# Altium schematic sheet 05-MICROCONTROLEUR.SchDoc(05-MICROCONTROLEUR)
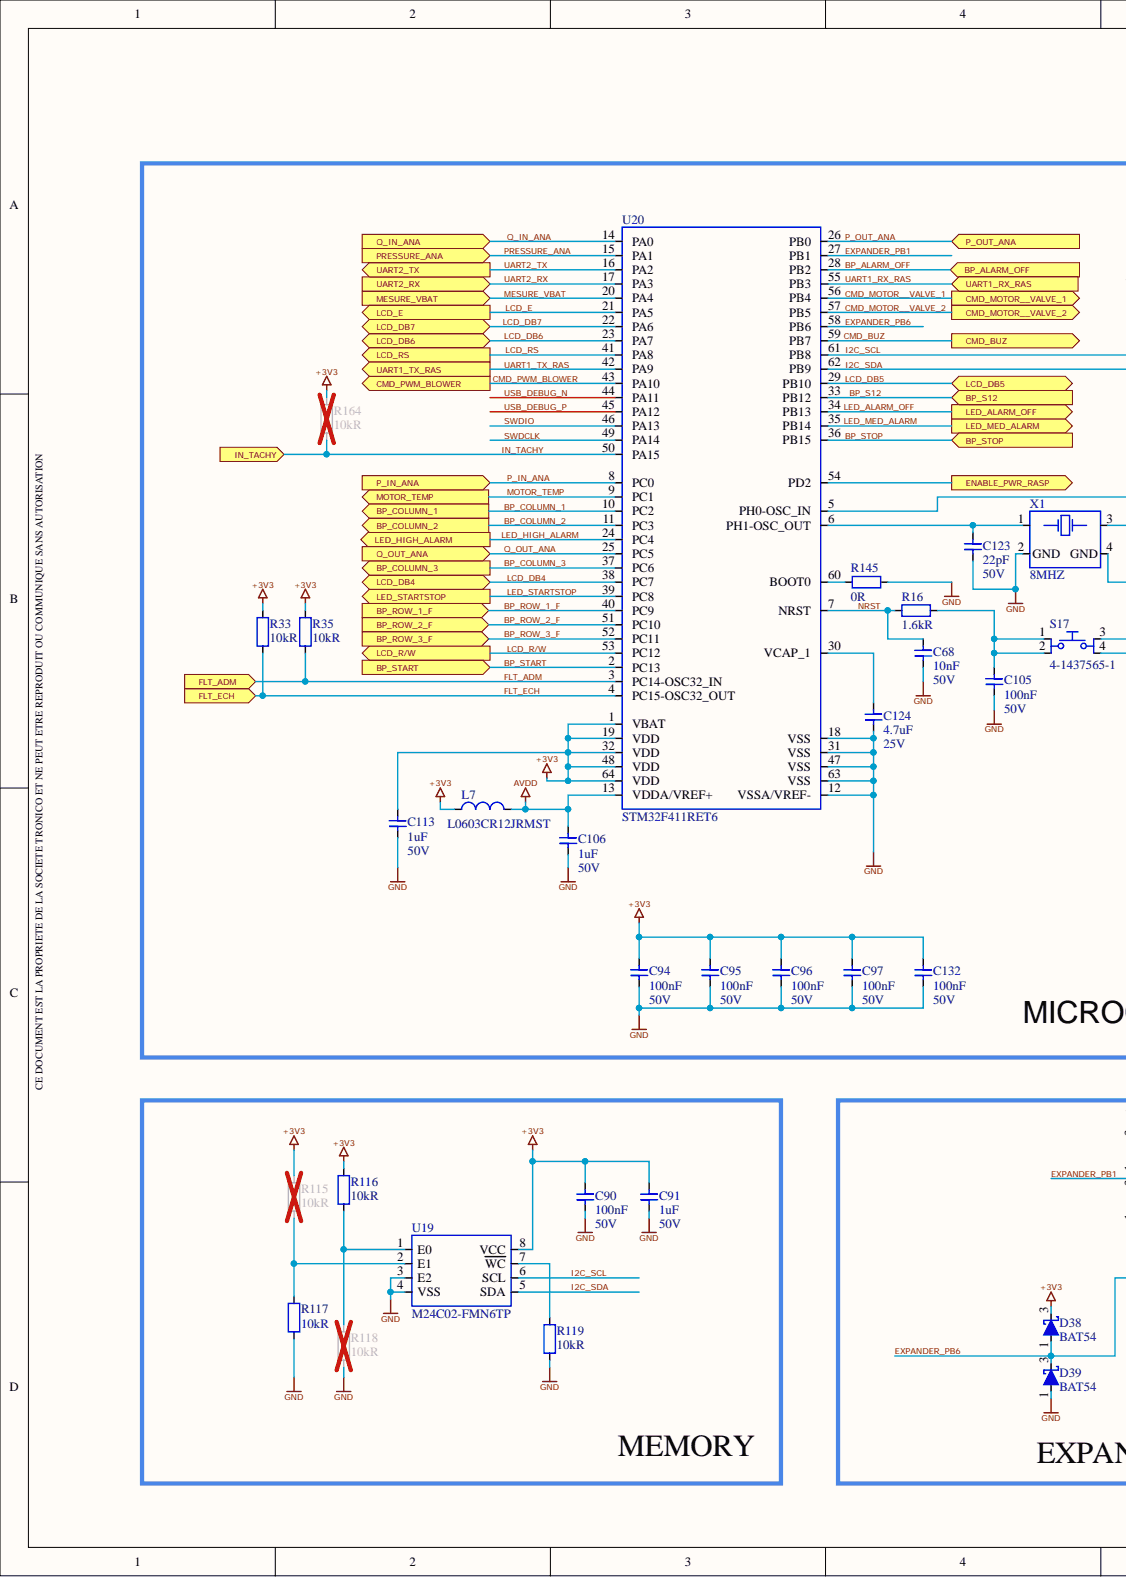
[diagram p0: 05-MICROCONTROLEUR.SchDoc, left]
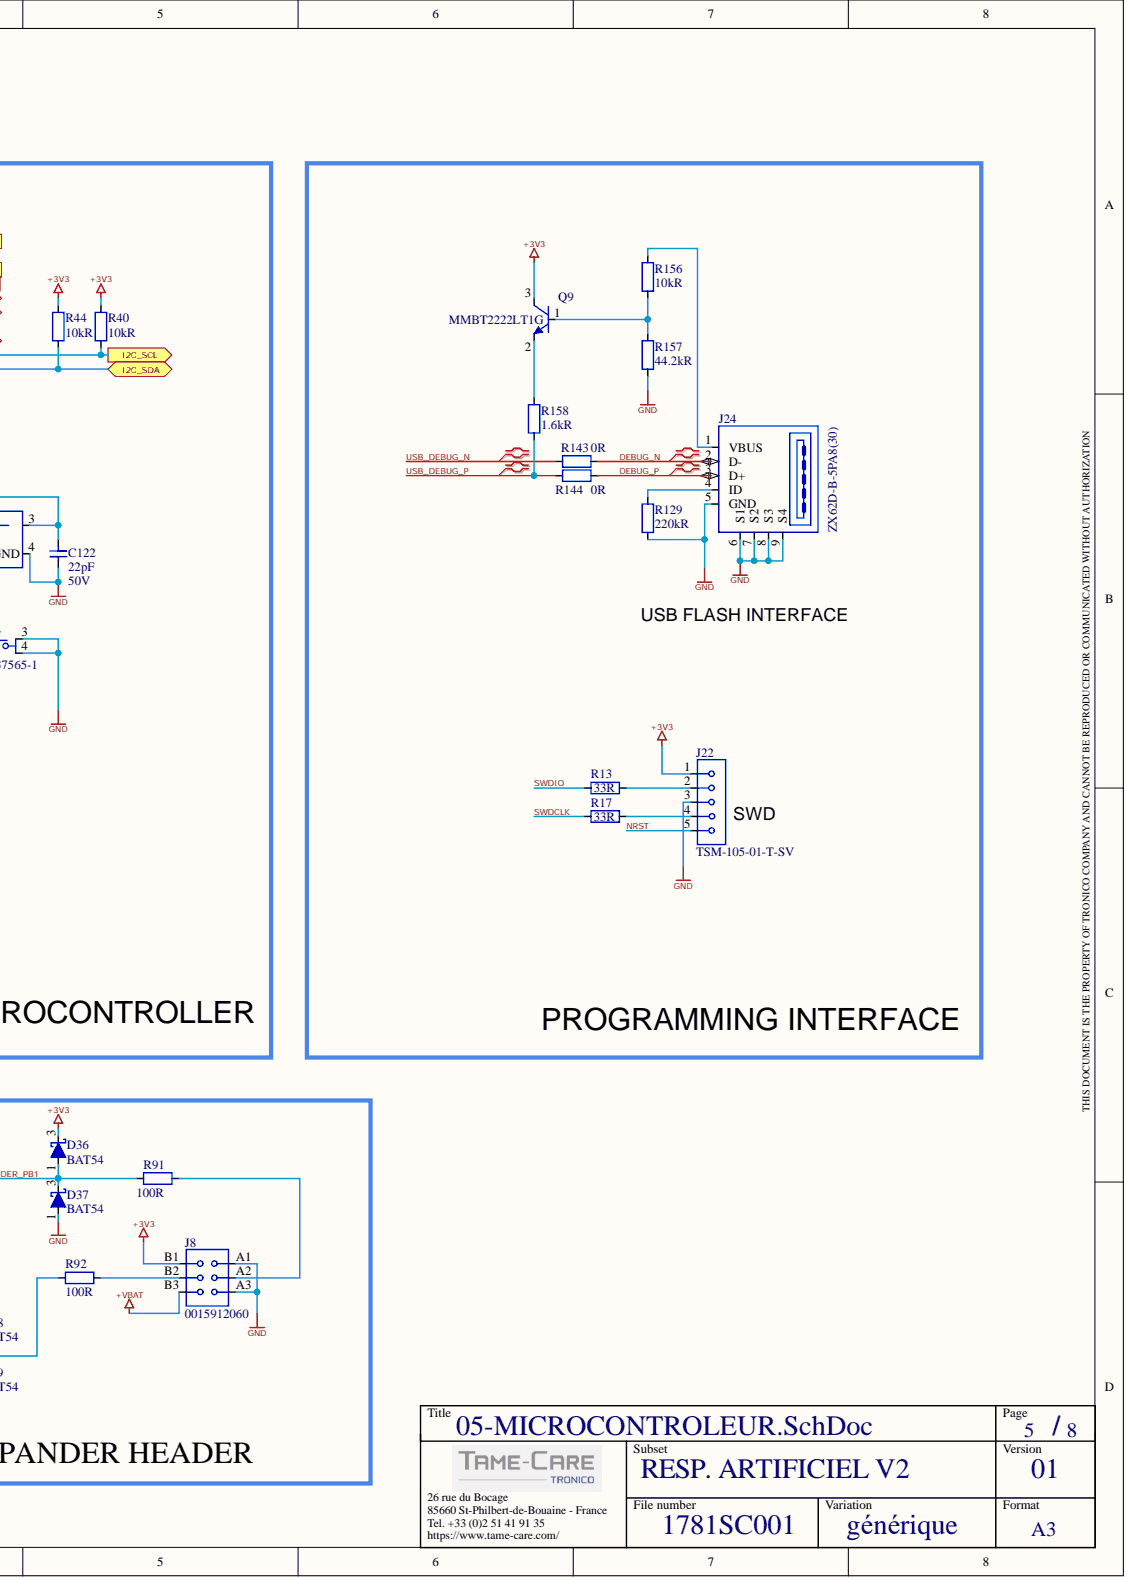
[diagram p1: 05-MICROCONTROLEUR.SchDoc, right]

## Parts
C68  10nF  Parameter 1: 50V  [CAPC_0603X080_T]
C90  100nF  Parameter 1: 50V  [CAPC_0603X094_T]
C91  1uF  Parameter 1: 50V  [CAPC_0603X080_T]
C94  100nF  Parameter 1: 50V  [CAPC_0603X094_T]
C95  100nF  Parameter 1: 50V  [CAPC_0603X094_T]
C96  100nF  Parameter 1: 50V  [CAPC_0603X094_T]
C97  100nF  Parameter 1: 50V  [CAPC_0603X094_T]
C105  100nF  Parameter 1: 50V  [CAPC_0603X094_T]
C106  1uF  Parameter 1: 50V  [CAPC_0603X080_T]
C113  1uF  Parameter 1: 50V  [CAPC_0603X080_T]
C122  22pF  Parameter 1: 50V  [CAPC_0603X090_T]
C123  22pF  Parameter 1: 50V  [CAPC_0603X090_T]
C124  4.7uF  Parameter 1: 25V  [CAPC_0603X080_T]
C132  100nF  Parameter 1: 50V  [CAPC_0603X094_T]
D36  BAT54  [SOT23_T]
D37  BAT54  [SOT23_T]
D38  BAT54  [SOT23_T]
D39  BAT54  [SOT23_T]
J8  0015912060  [CNT_MOLEX_15-91-2060_B]
J22  TSM-105-01-T-SV  [CNT_SAMTEC_TSM-105-01-X-SV_B]
J24  ZX62D-B-5PA8(30)  [CNT_HIROSE_ZX62D_B_5P8_B]
L7  L0603CR12JRMST  [IND_0603X080_T]
Q9  MMBT2222LT1G  [SOT23-DI]
R13  33R  [RESC_0603X045_T]
R16  1.6kR  [RESC_0603X045_T]
R17  33R  [RESC_0603X045_T]
R33  10kR  [RESC_0603X045_T]
R35  10kR  [RESC_0603X045_T]
R40  10kR  [RESC_0603X045_T]
R44  10kR  [RESC_0603X045_T]
R91  100R  [RESC_0603X045_T]
R92  100R  [RESC_0603X045_T]
R115  10kR  [RESC_0603X045_T]
R116  10kR  [RESC_0603X045_T]
R117  10kR  [RESC_0603X045_T]
R118  10kR  [RESC_0603X045_T]
R119  10kR  [RESC_0603X045_T]
R129  220kR  [RESC_0603X045_T]
R143  0R  [RESC_0603X045_T]
R144  0R  [RESC_0603X045_T]
R145  0R  [RESC_0603X045_T]
R156  10kR  [RESC_0603X045_T]
R157  44.2kR  [RESC_0603X045_T]
R158  1.6kR  [RESC_0603X045_T]
R164  10kR  [RESC_0603X045_T]
S17  4-1437565-1  [PushButon_TE-4-1437565-1_B]
U19  M24C02-FMN6TP  [SO8_1.27_5.0X4.0X1.75_B]
U20  STM32F411RET6  [LQFP64_0.5_10X10X1.6_B]
X1  8MHZ  [XTAL_ABRACON_ABMM2_B]

## Ports
BP_ALARM_OFF, BP_COLUMN_1, BP_COLUMN_2, BP_COLUMN_3, BP_ROW_1_F, BP_ROW_2_F, BP_ROW_3_F, BP_S12, BP_START, BP_STOP, CMD_BUZ, CMD_MOTOR__VALVE_1, CMD_MOTOR__VALVE_2, CMD_PWM_BLOWER, ENABLE_PWR_RASP, FLT_ADM, FLT_ECH, I2C_SCL, I2C_SDA, IN_TACHY, LCD_DB4, LCD_DB5, LCD_DB6, LCD_DB7, LCD_E, LCD_R/W, LCD_RS, LED_ALARM_OFF, LED_HIGH_ALARM, LED_MED_ALARM, LED_STARTSTOP, MESURE_VBAT, MOTOR_TEMP, P_IN_ANA, P_OUT_ANA, PRESSURE_ANA, Q_IN_ANA, Q_OUT_ANA, UART1_RX_RAS, UART1_TX_RAS, UART2_RX, UART2_TX

## Nets
+3V3: C90.2 C91.2 C94.2 C95.1 C96.2 C97.2 C113.2 C132.2 D36.3 D38.3 J8.B1 J22.1 L7.1 Q9.3 R33.1 R35.1 R40.2 R44.1 R115.1 R116.1 R164.1 U19.8(VCC) U20.1(VBAT) U20.19(VDD) U20.32(VDD) U20.48(VDD) U20.64(VDD)
+VBAT: J8.B3
AVDD: C106.2 L7.2 U20.13(VDDA/VREF+)
GND: C68.1 C90.1 C91.1 C94.1 C95.2 C96.1 C97.1 C105.1 C106.1 C113.1 C122.1 C123.1 C124.1 C132.1 D37.1 D39.1 J8.A1 J8.A3 J22.3 J24.5(GND) J24.6(S1) J24.7(S2) J24.8(S3) J24.9(S4) R117.2 R118.2 R119.2 R129.2 R145.1 R157.2 S17.3 S17.4 U19.3(E2) U19.4(VSS) U20.12(VSSA/VREF-) U20.18(VSS) U20.31(VSS) U20.47(VSS) U20.63(VSS) X1.2(GND) X1.4(GND)
BP_ALARM_OFF: U20.28(PB2) ; port BP_ALARM_OFF
BP_COLUMN_1: U20.10(PC2) ; port BP_COLUMN_1
BP_COLUMN_2: U20.11(PC3) ; port BP_COLUMN_2
BP_COLUMN_3: U20.37(PC6) ; port BP_COLUMN_3
BP_ROW_1_F: U20.40(PC9) ; port BP_ROW_1_F
BP_ROW_2_F: U20.51(PC10) ; port BP_ROW_2_F
BP_ROW_3_F: U20.52(PC11) ; port BP_ROW_3_F
BP_S12: U20.33(PB12) ; port BP_S12
BP_START: U20.2(PC13) ; port BP_START
BP_STOP: U20.36(PB15) ; port BP_STOP
CMD_BUZ: U20.59(PB7) ; port CMD_BUZ
CMD_MOTOR__VALVE_1: U20.56(PB4) ; port CMD_MOTOR__VALVE_1
CMD_MOTOR__VALVE_2: U20.57(PB5) ; port CMD_MOTOR__VALVE_2
CMD_PWM_BLOWER: U20.43(PA10) ; port CMD_PWM_BLOWER
DEBUG_N: J24.2(D-) R143.1
DEBUG_P: J24.3(D+) R144.1
ENABLE_PWR_RASP: U20.54(PD2) ; port ENABLE_PWR_RASP
EXPANDER_PB1: D36.1 D37.3 R91.2 U20.27(PB1)
EXPANDER_PB6: D38.1 D39.3 R92.2 U20.58(PB6)
FLT_ADM: R35.2 U20.3(PC14-OSC32_IN) ; port FLT_ADM
FLT_ECH: R33.2 U20.4(PC15-OSC32_OUT) ; port FLT_ECH
I2C_SCL: R40.1 U19.6(SCL) U20.61(PB8) ; port I2C_SCL
I2C_SDA: R44.2 U19.5(SDA) U20.62(PB9) ; port I2C_SDA
IN_TACHY: R164.2 U20.50(PA15) ; port IN_TACHY
LCD_DB4: U20.38(PC7) ; port LCD_DB4
LCD_DB5: U20.29(PB10) ; port LCD_DB5
LCD_DB6: U20.23(PA7) ; port LCD_DB6
LCD_DB7: U20.22(PA6) ; port LCD_DB7
LCD_E: U20.21(PA5) ; port LCD_E
LCD_R/W: U20.53(PC12) ; port LCD_R/W
LCD_RS: U20.41(PA8) ; port LCD_RS
LED_ALARM_OFF: U20.34(PB13) ; port LED_ALARM_OFF
LED_HIGH_ALARM: U20.24(PC4) ; port LED_HIGH_ALARM
LED_MED_ALARM: U20.35(PB14) ; port LED_MED_ALARM
LED_STARTSTOP: U20.39(PC8) ; port LED_STARTSTOP
MESURE_VBAT: U20.20(PA4) ; port MESURE_VBAT
MOTOR_TEMP: U20.9(PC1) ; port MOTOR_TEMP
NRST: C68.2 J22.5 R16.1 U20.7(NRST)
P_IN_ANA: U20.8(PC0) ; port P_IN_ANA
P_OUT_ANA: U20.26(PB0) ; port P_OUT_ANA
PRESSURE_ANA: U20.15(PA1) ; port PRESSURE_ANA
Q_IN_ANA: U20.14(PA0) ; port Q_IN_ANA
Q_OUT_ANA: U20.25(PC5) ; port Q_OUT_ANA
SWDCLK: R17.2 U20.49(PA14)
SWDIO: R13.2 U20.46(PA13)
UART1_RX_RAS: U20.55(PB3) ; port UART1_RX_RAS
UART1_TX_RAS: U20.42(PA9) ; port UART1_TX_RAS
UART2_RX: U20.17(PA3) ; port UART2_RX
UART2_TX: U20.16(PA2) ; port UART2_TX
USB_DEBUG_N: R143.2 U20.44(PA11)
USB_DEBUG_P: R144.2 R158.2 U20.45(PA12)
NetC105_2: C105.2 R16.2 S17.1 S17.2
NetC122_2: C122.2 U20.5(PH0-OSC_IN) X1.3
NetC123_2: C123.2 U20.6(PH1-OSC_OUT) X1.1
NetC124_2: C124.2 U20.30(VCAP_1)
NetJ8_A2: J8.A2 R91.1
NetJ8_B2: J8.B2 R92.1
NetJ22_2: J22.2 R13.1
NetJ22_4: J22.4 R17.1
NetJ24_1: J24.1(VBUS) R156.1
NetJ24_4: J24.4(ID) R129.1
NetQ9_1: Q9.1 R156.2 R157.1
NetQ9_2: Q9.2 R158.1
NetR115_2: R115.2 R117.1 U19.2(E1)
NetR116_2: R116.2 R118.1 U19.1(E0)
NetR119_1: R119.1 U19.7(WC)
NetR145_2: R145.2 U20.60(BOOT0)

## Library data of the parts
C68 = 23U00104: COND_CERAM CMS 0603 X7R 10nF 50V 10%; REV_NOTE: Maj Champs Techniques; REV_AUTHOR: nlelievre; REF_FAB: C0603C103K5RACTU; LEVEL: 1; FAMILLE: CAPACITIFS; FAB: KEMET; Database Table Name: v_bt_all; CHAMP9: -55°C/+125°C; CHAMP2: 0603; SS_FAMILLE: COND_CERAM; Simulation: CAP; Signal Integrity: Cap; REV_DATE: 16/10/2019 13:28:44; Library Name: SVNDatabase_BaseTechnique.SVNDbLib; ID_RnD: 23U00104; HelpURL: http://bt_rnd/ds/02_capacitif/KEMET/KEMET_KEM_C1002_X7R_SMD.pdf; ComponentLink2Description: Manufacturer Website; ComponentLink1Description: Manufacturer Datasheet; Component Kind: Standard; CHAMP7: 10%; CHAMP6: 50V; CHAMP5: 10nF; CHAMP3: X7R; CHAMP1: CMS; ACTIF: ACTIF
C90 C94-C97 C105 C132 = 23U00036: COND_CERAM CMS 0603 X7R 100nF 50V 10%; REV_NOTE: Maj Champs Techniques; REV_AUTHOR: nlelievre; REF_FAB: 06035C104KAT2A; LEVEL: 1; FAMILLE: CAPACITIFS; FAB: AVX; Database Table Name: v_bt_all; CHAMP9: -55°C/+125°C; CHAMP2: 0603; SS_FAMILLE: COND_CERAM; Simulation: CAP; Signal Integrity: Cap; REV_DATE: 16/10/2019 13:20:57; Library Name: SVNDatabase_BaseTechnique.SVNDbLib; ID_RnD: 23U00036; HelpURL: http://Bt_rnd/ds/02_capacitif/AVX/AVX_cx7r.pdf; ComponentLink2Description: Manufacturer Website; ComponentLink1Description: Manufacturer Datasheet; Component Kind: Standard; CHAMP7: 10%; CHAMP6: 50V; CHAMP5: 100nF; CHAMP3: X7R; CHAMP1: CMS; ACTIF: ACTIF
C91 C106 C113 = 23U00347: COND_CERAM CMS 0603 X5R 1uF 50V 10%; REV_NOTE: Maj Champs Techniques; REV_AUTHOR: nlelievre; REF_FAB: CGA3E3X5R1H105K080AB; LEVEL: 1; FAMILLE: CAPACITIFS; FAB: TDK; Database Table Name: v_bt_all; CHAMP9: -55°C/+85°C; CHAMP2: 0603; SS_FAMILLE: COND_CERAM; Simulation: CAP; Signal Integrity: Cap; REV_DATE: 16/10/2019 13:35:17; Library Name: SVNDatabase_BaseTechnique.SVNDbLib; ID_RnD: 23U00347; HelpURL: http://bt_rnd/ds/02_capacitif/TDK/mlccspec_automotive_general_midvoltage_en.pdf; ComponentLink2Description: Manufacturer Website; ComponentLink1Description: Manufacturer Datasheet; Component Kind: Standard; CHAMP7: 10%; CHAMP6: 50V; CHAMP5: 1uF; CHAMP3: X5R; CHAMP1: CMS; ACTIF: ACTIF
C122 C123 = 23U00046: COND_CERAM CMS 0603 C0G 22pF 50V 10%; REV_NOTE: MaJ Champs Techniques; REV_AUTHOR: lclavier; REF_FAB: C0603C220K5GACTU; LEVEL: 1; FAMILLE: CAPACITIFS; FAB: KEMET; Database Table Name: v_bt_all; CHAMP9: -55°C/+125°C; CHAMP2: 0603; SS_FAMILLE: COND_CERAM; Simulation: CAP; Signal Integrity: Cap; REV_DATE: 02/05/2020 13:19:47; Library Name: SVNDatabase_BaseTechnique.SVNDbLib; ID_RnD: 23U00046; HelpURL: http://Bt_rnd/ds/02_capacitif/AVX/AVX_cx7r.pdf; ComponentLink3URL: None; ComponentLink3Description: Qualification information; ComponentLink2URL: http://www.avx.com; ComponentLink2Description: Manufacturer Website; ComponentLink1URL: http://www.avx.com/docs/Catalogs/cx7r.pdf; ComponentLink1Description: Manufacturer Datasheet; Component Kind: Standard; CHAMP7: 10%; CHAMP6: 50V; CHAMP5: 22pF; CHAMP3: C0G; CHAMP1: CMS; ACTIF: ACTIF
C124 = 23U00282: COND_CERAM CMS 0603 X5R 4.7uF 25V 10%; REV_NOTE: Maj Champs Techniques; REV_AUTHOR: nlelievre; REF_FAB: GRM188R61E475KE15D; LEVEL: 1; FAMILLE: CAPACITIFS; FAB: MURATA; Database Table Name: v_bt_all; CHAMP9: -55°C/+85°C; CHAMP2: 0603; SS_FAMILLE: COND_CERAM; Simulation: CAP; Signal Integrity: Cap; REV_DATE: 16/10/2019 13:33:44; Library Name: SVNDatabase_BaseTechnique.SVNDbLib; ID_RnD: 23U00282; HelpURL: http://bt_rnd/ds/02_capacitif/murata/GRM18_series.pdf; ComponentLink2Description: Manufacturer Website; ComponentLink1Description: Manufacturer Datasheet; Component Kind: Standard; CHAMP7: 10%; CHAMP6: 25V; CHAMP5: 4.7uF; CHAMP3: X5R; CHAMP1: CMS; ACTIF: ACTIF
D36-D39 = 33U00013: DIODE_DE_SIGNAL CMS SOT23  30V 200mA -55°C/+150°C -55°C/+150°C; REV_NOTE: MàJ footprint_path et footprint_ref; REV_AUTHOR: llannuzel; QUALIF: None; LEVEL: 1; FAMILLE: DIODES; FAB: FAIRCHILD; Database Table Name: v_bt_all; SS_FAMILLE: DIODE_DE_SIGNAL; Simulation: SKYDIODE; Signal Integrity: D Schottky; REV_DATE: 13/12/2019 10:03:18; REF_FAB: BAT54; ID_RnD: 33U00013; HelpURL: http://bt_rnd/ds/03_diode/FAIRCHILD/BAT54_x.pdf; ComponentLink2Description: Manufacturer Website; ComponentLink1Description: Manufacturer Datasheet; Component Kind: Standard; CHAMP10: -55°C/+150°C; CHAMP9: -55°C/+150°C; CHAMP6: 200mA; CHAMP5: 30V; CHAMP2: SOT23; CHAMP1: CMS; ACTIF: ACTIF; Library Name: SVNDatabase_BaseTechnique.SVNDbLib
J8 = A7U00323: CONNECT C-Grid CMS 2x3PTS EMBASE RECT MALE DROIT; REV_NOTE: Migration vers t_bt; REV_AUTHOR: llannuzel; LEVEL: 1; FAMILLE: CONNECTIQUE; FAB: MOLEX; Database Table Name: v_bt_all; CHAMP9: -40°C/+105°C; SS_FAMILLE: CONNECT; REV_DATE: 03/05/2020 17:29:11; REF_FAB: 0015912060; ID_RnD: A7U00323; HelpURL: http://bt_rnd/ds/10_connectique/MOLEX/015912060_sd.pdf; ComponentLink2Description: Manufacturer Website; ComponentLink1Description: Manufacturer Datasheet; Component Kind: Standard; CHAMP8: DROIT; CHAMP7: MALE; CHAMP6: RECT; CHAMP5: EMBASE; CHAMP4: 2x3PTS; CHAMP3: CMS; CHAMP1: C-Grid; ACTIF: ACTIF; Library Name: SVNDatabase_BaseTechnique.SVNDbLib
J22 = A7U00320: CONNECT TSM CMS 5PTS EMBASE RECT MALE DROIT; Valide: OK; REV_NOTE: Migration vers t_bt; REV_AUTHOR: lclavier; LEVEL: 1; FAMILLE: CONNECTIQUE; FAB: SAMTEC; Database Table Name: v_bt_all; CHAMP9: -55°C/+105°C; SS_FAMILLE: CONNECT; REV_DATE: 24/04/2020 18:20:39; REF_FAB: TSM-105-01-T-SV; ID_RnD: A7U00320; HelpURL: http://bt_rnd/ds/10_connectique/SAMTEC/tsm-1xx-xx-xxx-sv-xx-xxx-xx-mkt.pdf; ComponentLink3URL: None; ComponentLink3Description: None; ComponentLink2URL: None; ComponentLink2Description: Manufacturer Website; ComponentLink1URL: http://bt_rnd/ds/10_connectique/SAMTEC/tsm-1xx-xx-xxx-sv-xx-xxx-xx-mkt.pdf; ComponentLink1Description: Footprint; Component Kind: Standard; CHAMP8: DROIT; CHAMP7: MALE; CHAMP6: RECT; CHAMP5: EMBASE; CHAMP4: 5PTS; CHAMP3: CMS; CHAMP1: TSM; ACTIF: ACTIF; Library Name: SVNDatabase_BaseTechnique.SVNDbLib
J24 = A7U00249: CONNECT USB CMS 5PTS EMBASE EMBASE RECT FEM COUDE -30°C/+85°C; REV_NOTE: MaJ Champs Techniques; REV_AUTHOR: lclavier; QUALIF: None; LEVEL: 1; FAMILLE: CONNECTIQUE; FAB: HIROSE; Database Table Name: v_bt_all; SS_FAMILLE: CONNECT; REV_DATE: 25/02/2019 13:48:49; REF_FAB: ZX62D-B-5PA8(30); Library Name: SVNDatabase_BaseTechnique.SVNDbLib; ID_RnD: A7U00249; HelpURL: http://bt_rnd/ds/10_connectique/hirose/ZX_Series.pdf; ComponentLink2Description: Manufacturer Website; ComponentLink1URL: https://www.hirose.com/product/en/products/ZX/ZX62D-B-5PA8(30)/; ComponentLink1Description: Manufacturer Datasheet; Component Kind: Standard; CHAMP9: -30°C/+85°C; CHAMP8: COUDE; CHAMP7: FEM; CHAMP6: RECT; CHAMP5: EMBASE; CHAMP4: 5PTS; CHAMP3: CMS; CHAMP1: USB; ACTIF: ACTIF
L7 = 42U00090: SELF CMS 0603  120nH 5% 300mA -40°C/+85°C; REV_NOTE: MAJ Champ HelpURL; REV_AUTHOR: lclavier; QUALIF: None; LEVEL: 1; FAMILLE: INDUCTIFS; FAB: KEMET; Database Table Name: v_bt_all; CHAMP2: 0603; SS_FAMILLE: SELF; Simulation: INDUCTOR; Signal Integrity: Inductor; REV_DATE: 13/03/2019 17:58:10; REF_FAB: L0603CR12JRMST; ID_RnD: 42U00090; HelpURL: http://BT_RND/ds/04_inductif/KEMET/Kemet_L-RMSSeries.pdf; ComponentLink2Description: Manufacturer Website; ComponentLink1Description: Manufacturer Datasheet; Component Kind: Standard; CHAMP9: -40°C/+85°C; CHAMP7: 300mA; CHAMP6: 5%; CHAMP5: 120nH; CHAMP1: CMS; ACTIF: ACTIF; Library Name: SVNDatabase_BaseTechnique.SVNDbLib
Q9 = 91U00003: TRANSISTOR_BIP CMS SOT23 NPN 30V +0.6A -55°C/+150°C -55°C/+150°C; REV_NOTE: Maj Library Ref & Library Path; REV_AUTHOR: jcaille; LEVEL: 1; FAMILLE: TRANSISTORS; FAB: ON SEMICONDUCTOR; Database Table Name: v_bt_all; SS_FAMILLE: TRANSISTOR_BIP; REV_DATE: 22/01/2020 16:00:13; REF_FAB: MMBT2222LT1G; ID_RnD: 91U00003; HelpURL: http://172.17.1.234/ds/09_transistor/ON%20semi/MMBT2222LT1-D.pdf; ComponentLink2Description: Manufacturer Website; ComponentLink1Description: Manufacturer Datasheet; Component Kind: Standard; CHAMP10: -55°C/+150°C; CHAMP9: -55°C/+150°C; CHAMP7: +0.6A; CHAMP6: 30V; CHAMP5: NPN; CHAMP2: SOT23; CHAMP1: CMS; ACTIF: ACTIF; Library Name: SVNDatabase_BaseTechnique.SVNDbLib
R13 R17 = 11U01018: REST CMS 0603 100PPM 33R 1/10W 5% 75V; Valide / Non Valide: OK; REV_NOTE: Migration vers t_bt; REV_AUTHOR: lclavier; REF_FAB: RC0603JR-0733RL; LEVEL: 1; FAMILLE: RESISTIFS; FAB: YAGEO; Database Table Name: v_bt_all; CHAMP9: -55°C/+155°C; CHAMP2: 0603; SS_FAMILLE: REST; Simulation: RESISTOR; Signal Integrity: Res; REV_DATE: 20/04/2020 18:36:50; ID_RnD: 11U01018; HelpURL: http://bt_rnd/ds/01_resistif/yageo/RC-L_Series.pdf; ComponentLink2Description: Manufacturer Website; ComponentLink1Description: Manufacturer Datasheet; Component Kind: Standard; CHAMP8: 75V; CHAMP7: 5%; CHAMP6: 1/10W; CHAMP5: 33R; CHAMP3: 100PPM; CHAMP1: CMS; ACTIF: ACTIF; Library Name: SVNDatabase_BaseTechnique.SVNDbLib
R16 R158 = 11U01012: REST CMS 0603 100PPM 1.6kR 1/10W 1% 75V; Valide / Non Valide: OK; REV_NOTE: Migration vers t_bt; REV_AUTHOR: jcaille; REF_FAB: CRCW06031K60FKEA; LEVEL: 1; FAMILLE: RESISTIFS; FAB: VISHAY; Database Table Name: v_bt_all; CHAMP9: -55°C/+155°C; CHAMP2: 0603; SS_FAMILLE: REST; Simulation: RESISTOR; Signal Integrity: Res; REV_DATE: 17/04/2020 11:05:27; ID_RnD: 11U01012; HelpURL: http://bt_rnd/ds/01_resistif/Vishay/dcrcwe3.pdf; ComponentLink2Description: Manufacturer Website; ComponentLink1Description: Manufacturer Datasheet; Component Kind: Standard; CHAMP8: 75V; CHAMP7: 1%; CHAMP6: 1/10W; CHAMP5: 1.6kR; CHAMP3: 100PPM; CHAMP1: CMS; ACTIF: ACTIF; Library Name: SVNDatabase_BaseTechnique.SVNDbLib
R33 R35 R40 R44 R115-R119 R156 R164 = 11U00108: REST CMS 0603 100PPM 10kR 1/10W 1% -55°C/+155°C; Valide / Non Valide: OK; REV_NOTE: MaJ description; REV_AUTHOR: jrenvoise; REF_FAB: RC0603FR-0710KL; LEVEL: 1; FAMILLE: RESISTIFS; FAB: YAGEO; Database Table Name: v_bt_all; CHAMP2: 0603; SS_FAMILLE: REST; Simulation: RESISTOR; Signal Integrity: Res; REV_DATE: 12/09/2018 10:54:26; ID_RnD: 11U00108; HelpURL: http://bt_rnd/ds/01_resistif/yageo/RC-L_Series.pdf; ComponentLink2Description: Manufacturer Website; ComponentLink1Description: Manufacturer Datasheet; Component Kind: Standard; CHAMP9: -55°C/+155°C; CHAMP7: 1%; CHAMP6: 1/10W; CHAMP5: 10kR; CHAMP3: 100PPM; CHAMP1: CMS; ACTIF: ACTIF; Library Name: SVNDatabase_BaseTechnique.SVNDbLib
R91 R92 = 11U00071: REST CMS 0603 100PPM 100R 1/10W 1% -55°C/+155°C; Valide / Non Valide: OK; REV_NOTE: MaJ description; REV_AUTHOR: jrenvoise; REF_FAB: RC0603FR-07100RL; LEVEL: 1; FAMILLE: RESISTIFS; FAB: YAGEO; Database Table Name: v_bt_all; CHAMP2: 0603; SS_FAMILLE: REST; Simulation: RESISTOR; Signal Integrity: Res; REV_DATE: 12/09/2018 10:54:26; ID_RnD: 11U00071; HelpURL: http://bt_rnd/ds/01_resistif/yageo/RC-L_Series.pdf; ComponentLink2Description: Manufacturer Website; ComponentLink1Description: Manufacturer Datasheet; Component Kind: Standard; CHAMP9: -55°C/+155°C; CHAMP7: 1%; CHAMP6: 1/10W; CHAMP5: 100R; CHAMP3: 100PPM; CHAMP1: CMS; ACTIF: ACTIF; Library Name: SVNDatabase_BaseTechnique.SVNDbLib
R129 = 11U01019: REST CMS 0603 100PPM 220kR 1/10W 1% 75V; Valide / Non Valide: OK; REV_NOTE: Migration vers t_bt; REV_AUTHOR: lclavier; REF_FAB: RC0603FR-07220KL; LEVEL: 1; FAMILLE: RESISTIFS; FAB: YAGEO; Database Table Name: v_bt_all; CHAMP9: -55°C/+155°C; CHAMP2: 0603; SS_FAMILLE: REST; Simulation: RESISTOR; Signal Integrity: Res; REV_DATE: 21/04/2020 09:23:29; ID_RnD: 11U01019; HelpURL: http://bt_rnd/ds/01_resistif/yageo/RC-L_Series.pdf; ComponentLink2Description: Manufacturer Website; ComponentLink1Description: Manufacturer Datasheet; Component Kind: Standard; CHAMP8: 75V; CHAMP7: 1%; CHAMP6: 1/10W; CHAMP5: 220kR; CHAMP3: 100PPM; CHAMP1: CMS; ACTIF: ACTIF; Library Name: SVNDatabase_BaseTechnique.SVNDbLib
R143-R145 = 11U00009: REST CMS 0603 100PPM  0R 1/10W 20mR -55°C/+155°C; Valide / Non Valide: OK; REV_NOTE: MaJ Champs URL; REV_AUTHOR: jrenvoise; REF_FAB: CRCW06030000Z0EA; LEVEL: 1; FAMILLE: RESISTIFS; FAB: VISHAY; Database Table Name: v_bt_all; CHAMP2: 0603; SS_FAMILLE: REST; Simulation: RESISTOR; Signal Integrity: Res; REV_DATE: 24/10/2018 10:43:14; ID_RnD: 11U00009; HelpURL: http://bt_rnd/ds/01_resistif/vishay/Vishay_D-CRCWE3.pdf; ComponentLink2Description: Manufacturer Website; ComponentLink1URL: http://www.vishay.com/docs/20035/dcrcwe3.pdf; ComponentLink1Description: Manufacturer Datasheet; Component Kind: Standard; CHAMP9: -55°C/+155°C; CHAMP8: 20mR; CHAMP6: 1/10W; CHAMP5: 0R; CHAMP3: 100PPM; CHAMP1: CMS; ACTIF: ACTIF; Library Name: SVNDatabase_BaseTechnique.SVNDbLib
R157 = 11U01013: REST CMS 0603 100PPM 44.2kR 1/10W 1% 75V; Valide / Non Valide: OK; REV_NOTE: MaJ Champs Techniques; REV_AUTHOR: jcaille; REF_FAB: CRCW060344K2FKEA; LEVEL: 1; FAMILLE: RESISTIFS; FAB: VISHAY; Database Table Name: v_bt_all; CHAMP9: -55°C/+155°C; CHAMP2: 0603; SS_FAMILLE: REST; Simulation: RESISTOR; Signal Integrity: Res; REV_DATE: 17/04/2020 12:14:56; Library Name: SVNDatabase_BaseTechnique.SVNDbLib; ID_RnD: 11U01013; HelpURL: http://bt_rnd/ds/01_resistif/Vishay/dcrcwe3.pdf; ComponentLink2Description: Manufacturer Website; ComponentLink1Description: Manufacturer Datasheet; Component Kind: Standard; CHAMP8: 75V; CHAMP7: 1%; CHAMP6: 1/10W; CHAMP5: 44.2kR; CHAMP3: 100PPM; CHAMP1: CMS; ACTIF: ACTIF
S17 = 81U00012: BOUTON_POUSSOIR CMS 4PTS DROIT 12Vc.a.  50mA; REV_NOTE: MaJ Champs Librairies; REV_AUTHOR: lclavier; LEVEL: 1; FAMILLE: COMMUTATION; FAB: TE CONNECTIVITY; Database Table Name: v_bt_all; CHAMP9: -35°C/+85°C; SS_FAMILLE: BOUTON_POUSSOIR; REV_DATE: 20/04/2020 18:16:49; REF_FAB: 4-1437565-1; ID_RnD: 81U00012; HelpURL: http://bt_rnd/ds/08_commutation/TE/0900766b814a3369.pdf; ComponentLink2Description: Manufacturer Website; ComponentLink1Description: Manufacturer Datasheet; Component Kind: Standard; CHAMP7: 12Vc.a.  50mA; CHAMP6: DROIT; CHAMP2: 4PTS; CHAMP1: CMS; ACTIF: ACTIF; Library Name: SVNDatabase_BaseTechnique.SVNDbLib
U19 = 64U00034: CI_MEMOIRE CMS SO8 EEPROM +1.7V/+5.5V 2kB I2C; Valide: OK; REV_NOTE: Maj Footprint Ref; REV_AUTHOR: jcaille; QUALIF: None; LEVEL: 1; FAMILLE: CIRCUITS_INTEGRES; FAB: ST MICROELECTRONICS; Database Table Name: v_bt_all; CHAMP10: -65°C/+150°C; CHAMP9: -40°C/+85°C; SS_FAMILLE: CI_MEMOIRE; REV_DATE: 12/02/2020 16:04:49; REF_FAB: M24C02-FMN6TP; ID_RnD: 64U00034; HelpURL: http://bt_rnd/ds/06_ci/ST/m24c02-f.pdf; ComponentLink2Description: Manufacturer Website; ComponentLink1Description: Manufacturer Datasheet; Component Kind: Standard; CHAMP8: I2C; CHAMP7: 2kB; CHAMP6: +1.7V/+5.5V; CHAMP5: EEPROM; CHAMP2: SO8; CHAMP1: CMS; ACTIF: ACTIF; Library Name: SVNDatabase_BaseTechnique.SVNDbLib
U20 = 65U00043: CI_PROC CMS LQFP64 uC +1.7V/+2.1V 8Bits 256kB; REV_NOTE: MaJ Champs URL; REV_AUTHOR: lclavier; LEVEL: 1; FAMILLE: CIRCUITS_INTEGRES; FAB: ST; Database Table Name: v_bt_all; CHAMP10: -60°C/+150°C; CHAMP9: -40°C/+85°C; SS_FAMILLE: CI_PROC; REV_DATE: 07/04/2020 14:22:15; REF_FAB: STM32F411RET6; ID_RnD: 65U00043; HelpURL: http://bt_rnd/ds/06_ci/ST/stm32f411re.pdf; ComponentLink1URL: https://www.st.com/en/microcontrollers-microprocessors/stm32f411re.html; Component Kind: Standard; CHAMP8: 256kB; CHAMP7: 8Bits; CHAMP6: +1.7V/+2.1V; CHAMP5: uC; CHAMP2: LQFP64; CHAMP1: CMS; ACTIF: ACTIF; Library Name: SVNDatabase_BaseTechnique.SVNDbLib
X1 = 73U00029: QUARTZ CMS 6.0x3.6MM 8MHZ  20PPM; REV_NOTE: MaJ Champs Librairies; REV_AUTHOR: llannuzel; REF_FAB: ABMM2-8.000MHZ-E2-T; QUALIF: None; LEVEL: 1; FAMILLE: PIEZO; FAB: ABRACON; Database Table Name: v_bt_all; CHAMP10: -40°C/+85°C; CHAMP9: -20°C/+70°C; CHAMP8: 18pF; SS_FAMILLE: QUARTZ; REV_DATE: 30/04/2019 08:07:37; ID_RnD: 73U00029; HelpURL: http://BT_RND/ds/07_piezo/ABRACON/ABMM2.pdf; ComponentLink3Description: Qualification information; ComponentLink2Description: Manufacturer Website; ComponentLink1Description: Manufacturer Datasheet; Component Kind: Standard; CHAMP7: 20PPM; CHAMP5: 8MHZ; CHAMP2: 6.0x3.6MM; CHAMP1: CMS; ACTIF: ACTIF; Library Name: SVNDatabase_BaseTechnique.SVNDbLib
